# Altium schematic sheet Sheet1.SchDoc(Sheet1)
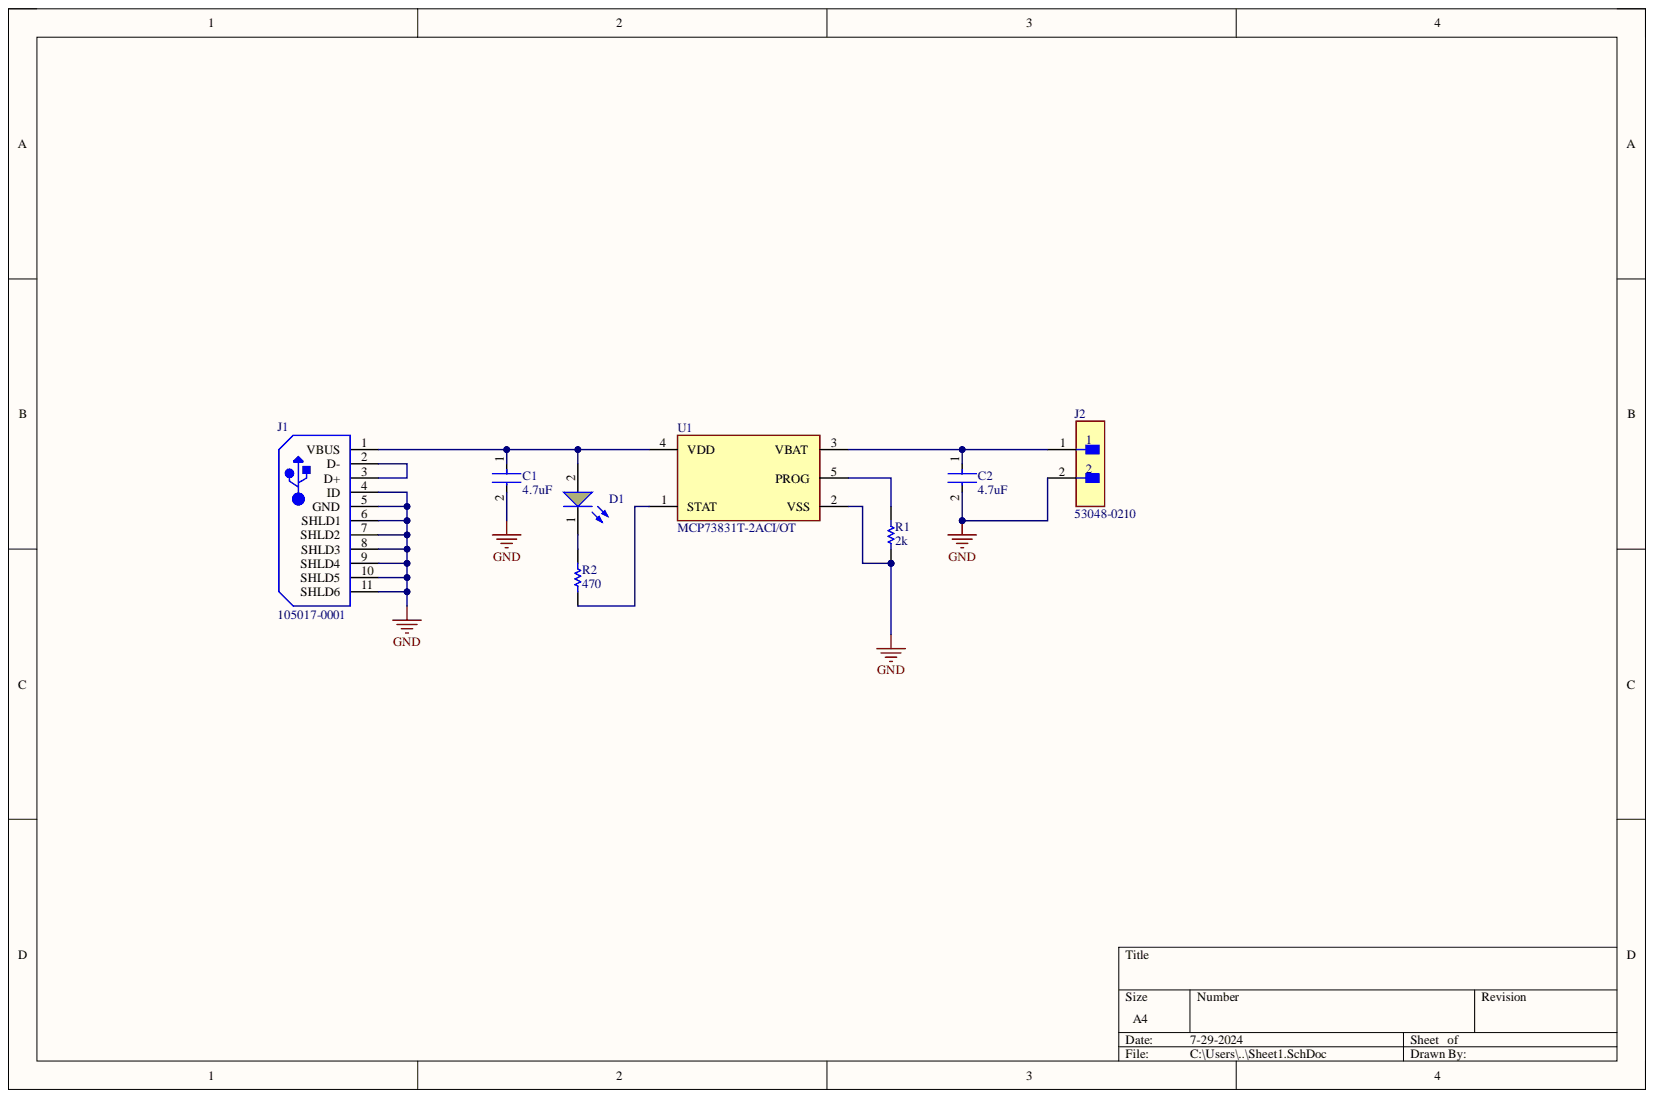
[diagram p0: Sheet1.SchDoc]

## Parts
C1  4.7uF  [FP-0603-L_1_6_0_15-W_0_81-MFG]
C2  4.7uF  [FP-0603-L_1_6_0_15-W_0_81-MFG]
D1  150060AS75000  [WL-SMCW_0603_150060xx75000]  (value not drawn)
J1  105017-0001  [USB-MICRO-B_V]
J2  53048-0210  [FP-53048-0210-MFG]
R1  2k  [RESC1608X55X25LL10T15]
R2  470  [RESC1608X55X25LL10T15]
U1  MCP73831T-2ACI/OT  [FP-C04-091-OT-IPC_B]

## Nets
GND: C1.2 C2.2 J1.4(ID) J1.5(GND) J1.6(SHLD1) J1.7(SHLD2) J1.8(SHLD3) J1.9(SHLD4) J1.10(SHLD5) J1.11(SHLD6) J2.2 R1.1 U1.2(VSS)
NetC1_1: C1.1 D1.2 J1.1(VBUS) U1.4(VDD)
NetC2_1: C2.1 J2.1 U1.3(VBAT)
NetD1_1: D1.1 R2.2
NetJ1_2: J1.2(D-) J1.3(D+)
NetR1_2: R1.2 U1.5(PROG)
NetR2_1: R2.1 U1.1(STAT)

## Library data of the parts
C1 C2 = CMP-2006-04567-2: General Purpose Ceramic Capacitor, 0603, 4.7uF, 10%, X5R, 15%, 10V; Dissipation Factor: 3.5%; Capacitance: 4.7uF; Case Code (Imperial): 0603; Case Code (Metric): 1608; Case/Package: 0603; Component Kind: Standard; Construction: Flat; Contact Plating: Tin; Depth: 0.81mm; Dielectric: X5R; Height: 0.9mm; Lead Free: Lead Free; Length: 1.6mm; Library Name: Altium Content Vault; Max Operating Temperature: 85°C; Min Operating Temperature: -55°C; Mount: Surface Mount; Number of Pins: 2; Package Quantity: 1; Packaging: Tape and Reel; Radiation Hardening: No; REACH SVHC: No SVHC; RoHS Compliant: Yes; Series: -; Termination: SMD/SMT; Thickness: 0.035inch; Tolerance: 10%; Voltage: 10V; Voltage Rating: 10V; Voltage Rating (DC): 10V; Width: 0.81mm
D1 = CMP-03913-89970694-1: 150060AS75000 WL-SMCW SMT Mono-Color Chip LED Waterclear; Dominant Wavelength: 605nm; Forward Current: 100mA; Forward Voltage: 2V; Luminous Intensity: 130mcd; Max Reverse Voltage (DC): 5V; Peak Wavelength: 610nm; Viewing Angle: 140°; Component Kind: Standard; Library Name: Altium Content Vault; Max Operating Temperature: 85°C; Min Operating Temperature: -40°C; NexarPartId: 89970694; Packaging: Tape and Reel; RoHS: Compliant; Wavelength: 605nm
J1 = CMP-2000-05827-1: Micro-USB B Receptacle, Right Angle, Bottom Mount, Surface Mount, with Solder Tabs, -30 to 85 degC, 5-Pin USB, RoHS, Tape and Reel; Manufacturer: Molex; 3D Model: 105017-0001.stp; Catalog Drawings: 1050170001; Circuits: 5; Connector Type: USB-microB; Featured Product: Micro-USBConnectorsUSBConnectors; Mating Products: WM17145-ND-CABLEMICROUSBBTOSTDA1.0MWM17147-ND-CABLEMICROUSBBTOSTDA2.0MWM17146-ND-CABLEMICROUSBBTOSTDA1.5M; Online Catalog: Molex-MicroUSB; Other Names: WM1399DKR; Package Version: Rev. F, 12/2012; PCN Assembly/Origin: ResinSupplier21/Oct/2015; Voltage - Max: 30V; Category: Connectors,Interconnects; Component Kind: Standard; Datasheet URL: http://www.molex.com/elqNow/elqRedir.htm?ref=http://www.molex.com/webdocs/datasheets/pdf/en-us/1050170001_IO_CONNECTORS.pdf; Datasheet Version: 03/2016; Family: USB,DVI,HDMIConnectors; Features: Shielded,SolderRetention; Gender: Receptacle; Ingress Protection: -; Library Name: Altium Content Vault; Manufacturer URL: http://www.molex.com/; Mounting Technology: SurfaceMount; Mounting Type: BoardCutout,SurfaceMount,RightAngle; Number of Contacts: 5; Package Description: 5-Leads USB, Micro B, Body 7.5 x 5 mm, Pitch 0.65 mm; Package Reference: MICRO-B; Package URL: http://www.molex.com/pdm_docs/sd/1050170001_sd.pdf; Packaging: Digi-Reel®; RoHS Information: 1050170001CertofCompliance; Series: -; Specifications: -; Standard Package: 1
J2 = CMP-04776-000546-1: CONN HEADER R/A 2POS 1.25MM; Contact Resistance: 20mR; Current Rating: 1A; Gender: Male; Glow Wire Compliant: Non-compliant; Insulation Resistance: 100MR; Number of Circuits: 2; Voltage Rating: 125V; Color: Natural; Component Kind: Standard; Contact Material: Bronze; Contact Plating: Tin; Flammability Rating: UL94 V-0; Housing Color: Natural; Housing Material: Nylon; Lead Free: Lead Free; Library Name: Altium Content Vault; Max Operating Temperature: 85°C; Min Operating Temperature: -40°C; Mount: Through Hole; Number of Contacts: 2; Number of Positions: 2; Number of Rows: 1; Orientation: Right Angle; Package Quantity: 5000; Packaging: Tray; Pitch: 1.25mm; Radiation Hardening: No; RoHS Compliant: Yes; Series: PicoBlade; Termination: Through Hole; Voltage Rating (DC): 125V
R1 = CMP-2000-06937-1: Chip Resistor, 2 KOhm, +/- 1%, 50 V, -55 to 155 degC, 0603 (1608 Metric), RoHS, Tape and Reel; Manufacturer: Yageo; Catalog Drawings: ThickFilmResistorTopThickFilmResistorSide; EDA / CAD Models: DownloadfromAcceleratedDesigns; HTML Datasheet: RCSeries,LSuffixDatasheet.html; Kits: PHH4-KIT-ND-RESKIT1K-9.76K1/10W5700PCS; Online Catalog: RC0603Series; Other Names: 311-2.00KHRCT; PCN Other: RC/AC0603/0805/120622/Jan/2013; Power (Watts): 0.1W,1/10W; Temperature Coefficient: ±100ppm/°C; Category: Resistors; Component Kind: Standard; Composition: ThickFilm; Datasheet URL: http://www.yageo.com/documents/recent/PYu-RC_Group_51_RoHS_L_7.pdf; Datasheet Version: Rev. 7, 05/2017; Family: ChipResistor-SurfaceMount; Features: MoistureResistant; Height: 0.022"(0.55mm); Library Name: Altium Content Vault; Manufacturer URL: http://www.yageo.com/; Mounting Technology: SurfaceMount; Number of Terminations: 2; Operating Temperature: -55°C~155°C; Package / Case: 0603(1608Metric); Package Description: 2-Pin Surface Mount Device, Body 1.6 x 0.8 mm; Package Reference: 0603; Packaging: CutTape(CT); Product Training Modules: ChipResistor; Resistance (Ohms): 2k; Series: RC; Size / Dimension: 0.063"Lx0.031"W(1.60mmx0.80mm); Standard Package: 1; Supplier Device Package: 0603; Tolerance: ±1%
R2 = CMP-1659-00035-2: General Purpose Chip Resistor, 470 Ohm, +/- 1%, -55 to 155 degC, 0603 (1608 Metric), RoHS, Tape and Reel; Resistance: 470 Ohm; Component Kind: Standard; ComponentLink1Description: Manufacturer URL; ComponentLink1URL: http://www.yageo.com/; ComponentLink2Description: Datasheet; ComponentLink2URL: https://datasheet.ciiva.com/11808/0900766b80f96399-11808177.pdf; Contact Material: Brass; Contact Plating: Gold; DatasheetVersion: Rev. 4, 04/2009; Fastening Type: Snap; Height: 1.25 mm; Length: 3 mm; Library Name: Altium Content Vault; Max Operating Temperature: 90 degC; Min Operating Temperature: -40 degC; Mount: Surface Mount; Package Quantity: 2500; PackageDescription: 2-Pin Surface Mount Device, Body 1.6 x 0.8 mm; PackageReference: 0603; Packaging: Tape and Reel; Radiation Hardening: No; RoHSCompliant: true; Width: 3.1 mm
U1 = CMP-0133-00143-2: IC CONTROLLR LI-ION 4.2V SOT23-5; Drain to Source Resistance: 350mR; Max Charge Current: 500mA; Max Input Voltage: 6V; Max Output Voltage: 4.5V; Max Power Dissipation: 1.54W; Min Output Voltage: 4.168V; Min Supply Voltage: 3.75V; Number of Cells: 1; Voltage Rating: 4.534V; Case/Package: SOT-23; Component Kind: Standard; Contact Plating: Tin; Height: 0.051inch; Lead Free: Lead Free; Length: 0.122inch; Library Name: Altium Content Vault; Max Operating Temperature: 85°C; Max Supply Voltage: 6V; Min Operating Temperature: -40°C; Mount: Surface Mount; Number of Channels: 1; Number of Outputs: 1; Number of Pins: 5; Output Current: 500mA; Output Voltage: 4.2V; Packaging: Tape and Reel; Radiation Hardening: No; REACH SVHC: No SVHC; Termination: SMD/SMT; Weight: 0.00709oz; Width: 0.071inch
